# Altium schematic sheet Power.SchDoc(Power)
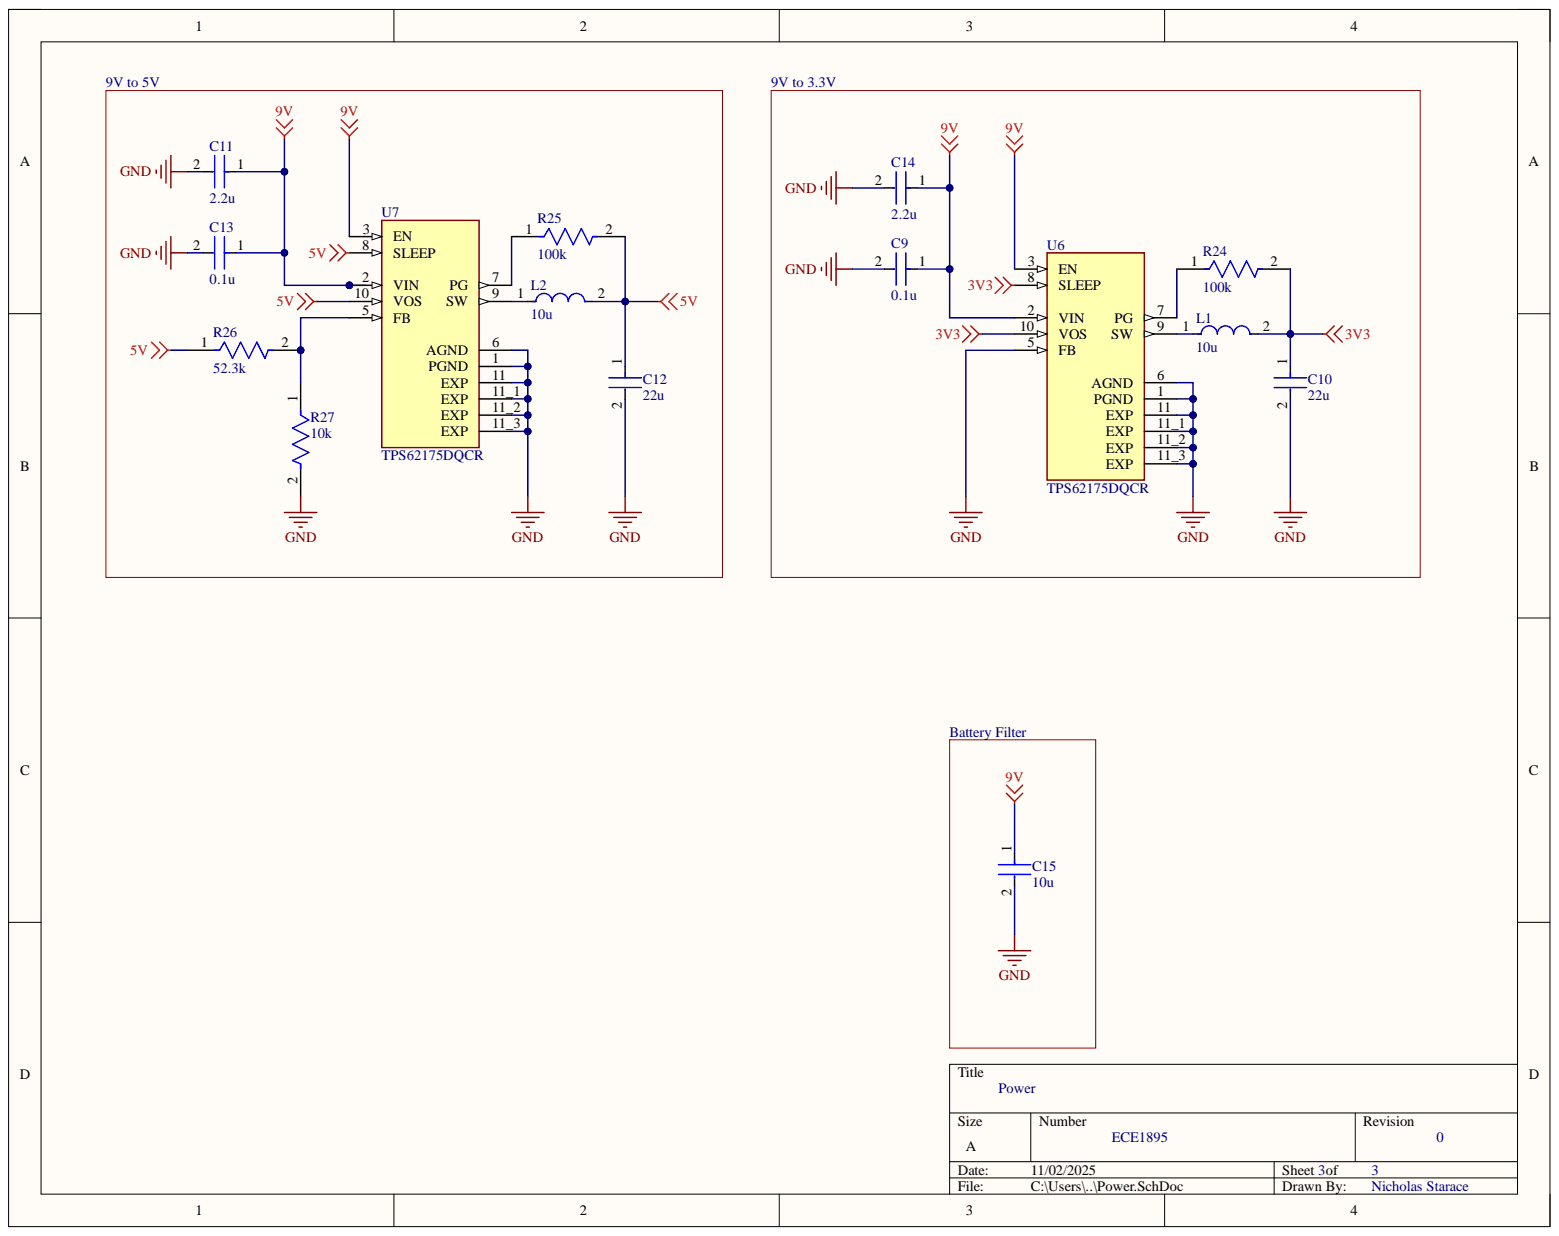
[diagram p0: Power.SchDoc]

## Parts
C9  0.1u  [FP-GRM21B-0_2-e0_2_0_7-IPC_B]
C10  22u  [FP-GRM21B-0_2-e0_2_0_7-IPC_B]
C11  2.2u  [FP-GRM21B-0_2-e0_2_0_7-IPC_B]
C12  22u  [FP-GRM21B-0_2-e0_2_0_7-IPC_B]
C13  0.1u  [FP-GRM21B-0_2-e0_2_0_7-IPC_B]
C14  2.2u  [FP-GRM21B-0_2-e0_2_0_7-IPC_B]
C15  10u  [FP-GRM21B-0_2-e0_2_0_7-IPC_B]
L1  10u  [FP-LQM21DN_70-MFG]
L2  10u  [FP-LQM21DN_70-MFG]
R24  100k  [FP-TFCR0805-MFG]
R25  100k  [FP-TFCR0805-MFG]
R26  52.3k  [FP-TFCR0805-MFG]
R27  10k  [FP-TFCR0805-MFG]
U6  TPS62175DQCR  [SON50P300X200X80-11N240X84]
U7  TPS62175DQCR  [SON50P300X200X80-11N240X84]

## Nets
3V3: C10.1 L1.2 R24.2 U6.8(SLEEP) U6.10(VOS)
5V: C12.1 L2.2 R25.2 R26.1 U7.8(SLEEP) U7.10(VOS)
9V: C9.1 C11.1 C13.1 C14.1 C15.1 U6.2(VIN) U6.3(EN) U7.2(VIN) U7.3(EN)
GND: C9.2 C10.2 C11.2 C12.2 C13.2 C14.2 C15.2 R27.2 U6.1(PGND) U6.5(FB) U6.6(AGND) U6.11(EXP) U6.11_1(EXP) U6.11_2(EXP) U6.11_3(EXP) U7.1(PGND) U7.6(AGND) U7.11(EXP) U7.11_1(EXP) U7.11_2(EXP) U7.11_3(EXP)
NetL1_1: L1.1 U6.9(SW)
NetL2_1: L2.1 U7.9(SW)
NetR24_1: R24.1 U6.7(PG)
NetR25_1: R25.1 U7.7(PG)
NetR26_2: R26.2 R27.1 U7.5(FB)

## Library data of the parts
C9-C15 = CMP-06035-063309-1: CAP CER 2.2UF 100V X7T 0805; Component Kind: Standard; Library Name: Altium Content Vault
L1 L2 = CMP-00260-111578617-1: LQM21DN330M70L Multilayer Inductor, 33 µH, 2.99 ohm, 16 MHz, 200 mA, 0805 [2012 Metric], LQM; Manufacturer Lifecycle Status: IN PRODUCTION NEW; Core Material: Ferrite; Current Rating: 200mA; Inductance: 33µH; Resistance: 2.99Ω; Saturation Current: 80mA; Self Resonant Frequency: 16MHz; Test Frequency: 1MHz; Tolerance: 20%; Case/Package: 0805; Component Kind: Standard; Height: 1.45mm; Library Name: Altium Content Vault; Max Operating Temperature: 125°C; Min Operating Temperature: -55°C; NexarPartId: 111578617; RMS Current (Irms): 200mA; Schedule B: 8504500000, 8504500000; Series Resistance: 2.99Ω
R24-R27 = CMP-250906-142014-1: RES Thin Film,  1.72kΩ, 1%, 0.125W, 25ppm/°C, 0805; Component Kind: Standard; Library Name: Altium Content Vault
U6 U7 = TPS62175DQCR: 28V, 0.5A Step-Down Converter with SNOOZE Mode 10-WSON -40 to 85; MF: Texas Instruments; Availability: Good; Check_prices: https://www.snapeda.com/parts/TPS62175DQCR/Texas%20Instruments/view-part/494000/?ref=eda; Component Kind: Standard; Library Name: TPS62175DQCR.IntLib; MP: TPS62175DQCR; Package: WSON-10 Texas Instruments; Price: None; Purchase-URL: https://pricing.snapeda.com/search/part/TPS62175DQCR/?ref=eda; SnapEDA_Link: https://www.snapeda.com/parts/TPS62175DQCR/Texas%20Instruments/view-part/494000/?ref=snap
